# Altium schematic sheet cgs81-2.schdoc(cgs81-2)
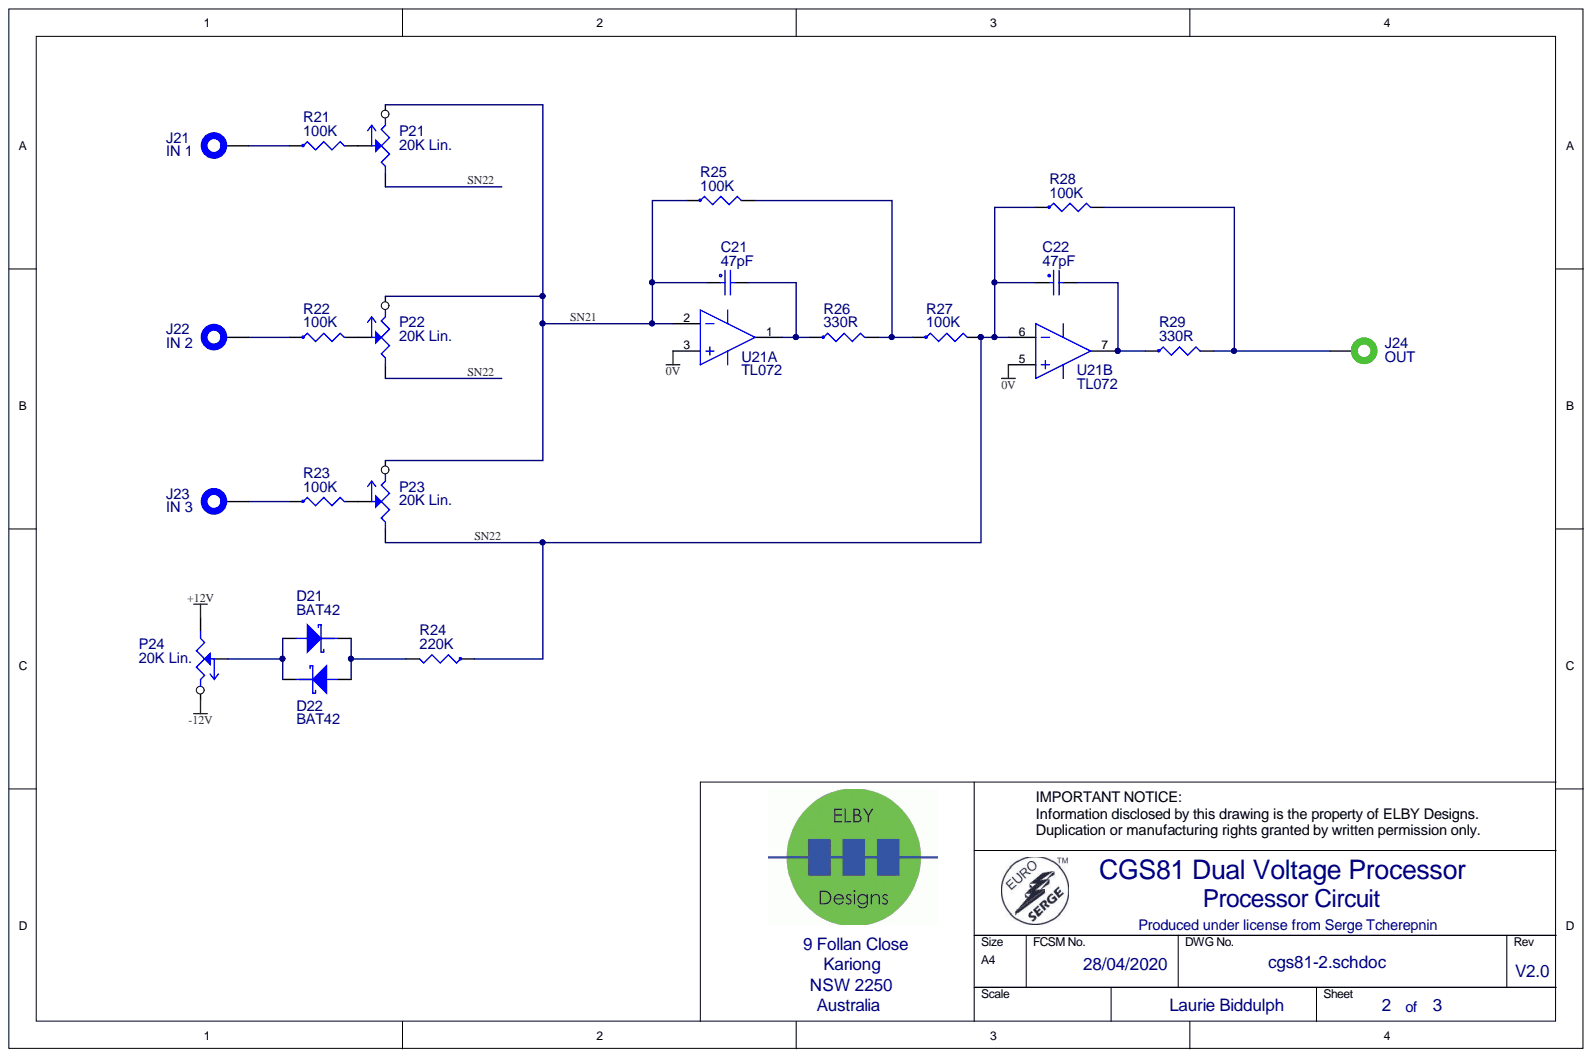
[diagram p0: cgs81-2.schdoc]

## Parts
C21  47pF  [RAD-0.2N(LB)]
C22  47pF  [RAD-0.2N(LB)]
D21  BAT42  [DIODE-0.3(LB)]
D22  BAT42  [DIODE-0.3(LB)]
J21  IN 1  [CGS-WIRE(LB)]
J22  IN 2  [CGS-WIRE(LB)]
J23  IN 3  [CGS-WIRE(LB)]
J24  OUT  [CGS-WIRE(LB)]
P21  20K Lin.  [CGS-POT(LB)]
P22  20K Lin.  [CGS-POT(LB)]
P23  20K Lin.  [CGS-POT(LB)]
P24  20K Lin.  [CGS-POT(LB)]
R21  100K  [AXIAL-0.4(LB)]
R22  100K  [AXIAL-0.4(LB)]
R23  100K  [AXIAL-0.4(LB)]
R24  220K  [AXIAL-0.4(LB)]
R25  100K  [AXIAL-0.4(LB)]
R26  330R  [AXIAL-0.4(LB)]
R27  100K  [AXIAL-0.4(LB)]
R28  100K  [AXIAL-0.4(LB)]
R29  330R  [AXIAL-0.4(LB)]
U21  TL072  [DIP-8(LB)]  (parts A,B)

## Nets
0V: U21.3 U21.5
+12V: P24.3
-12V: P24.1
SN21: C21.1 P21.1 P22.1 P23.1 R25.1 U21.2
SN22: C22.1 P21.3 P22.3 P23.3 R24.1 R27.2 R28.1 U21.6
NetC21_2: C21.2 R26.1 U21.1
NetC22_2: C22.2 R29.1 U21.7
NetD21_A: D21.A D22.K P24.2
NetD21_K: D21.K D22.A R24.2
NetJ21_1: J21.1 R21.1
NetJ22_1: J22.1 R22.1
NetJ23_1: J23.1 R23.1
NetJ24_1: J24.1 R28.2 R29.2
NetP21_2: P21.2 R21.2
NetP22_2: P22.2 R22.2
NetP23_2: P23.2 R23.2
NetR25_2: R25.2 R26.2 R27.1

## Library data of the parts
C21 C22 = CC-47PF(LB): NPO Low K, 50VDC Ceramic Disc; Library Name: capacitor.schlib; EMRP: CC-47PF; Component Kind: Standard
D21 D22 = BAT42(LB): Schottky Diode; EMRP: SD-BAT42; Library Name: semi.schlib; Component Kind: Standard
J21-J23 = BANANA-BLUE: 4mm Banana Socket; Library Name: connectors.schlib; EMRP: ?; Component Kind: Standard
J24 = BANANA-GREEN: 4mm Banana Socket; Library Name: connectors.schlib; EMRP: ?; Component Kind: Standard
P21-P24 = RP-20KLN(LB): Alpha 16S LIN(B); EMRP: RP-1-20KLN; Library Name: potentiometer.schlib; Component Kind: Standard
R21-R23 R25 R27 R28 = RM-100K(LB): 0.5W 1% Metal Film Resistor; Library Name: resistor.schlib; EMRP: RM-100K; Component Kind: Standard
R24 = RM-220K(LB): 0.5W 1% Metal Film Resistor; Library Name: resistor.schlib; EMRP: RM-220K; Component Kind: Standard
R26 R29 = RM-330R(LB): 0.5W 1% Metal Film Resistor; Simulation: 330R; Library Name: resistor.schlib; EMRP: RM-330R; Component Kind: Standard
U21 = TL072(LB): Dual Low-noise JFET Op-amp; EMRP: SI-3-TL072; Library Name: ic.schlib; Component Kind: Standard
